# Altium schematic sheet DC Step-Down Converter.SchDoc
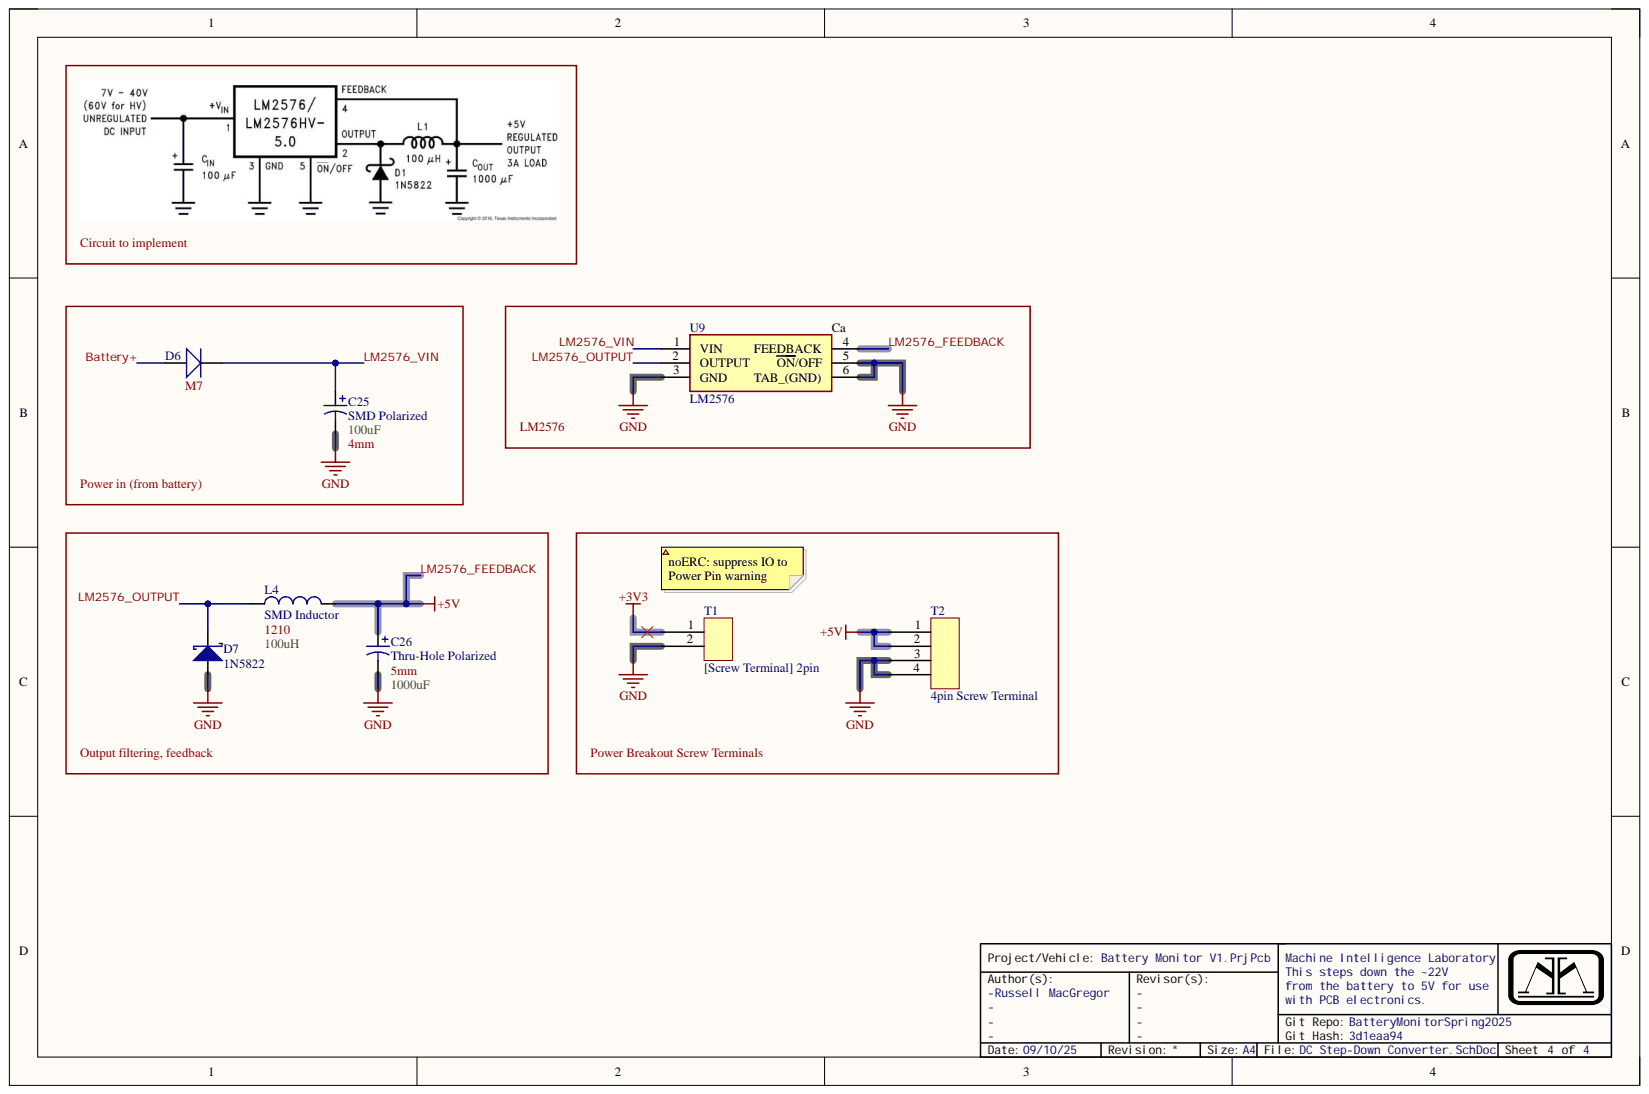
[diagram p0: DC Step-Down Converter.SchDoc]

## Parts
C25  SMD Polarized  Capacitance: 100uF  Diameter: 4mm  [[Cap] SMD Polarized, 4mm]
C26  Thru-Hole Polarized  Capacitance: 1000uF  Pin Spacing: 5mm  [[Cap] Thru-Hole Polarized 5mm]
D6  Size Package: M7  [[Diode] SMD M7]  (hidden comment: Rectifier)
D7  1N5822  [[Diode] Thru-Hole Schottky]
L4  SMD Inductor  Size Package: 1210  [[Inductor] SMD 1210]
T1  [Screw Terminal] 2pin  [[Screw Terminal] 2pin]
T2  4pin Screw Terminal  [[Screw Terminal] 4pin]
U9  LM2576  [[IC] Buck Converter]

## Nets
+3V3: T1.1
GND: C25.2 C26.2 D7.2 T1.2 T2.3 T2.4 U9.3(GND) U9.5(ON/OFF) U9.6(TAB_(GND))
Battery+: D6.2
LM2576_FEEDBACK: C26.1 L4.2 T2.1 T2.2 U9.4(FEEDBACK)
LM2576_OUTPUT: D7.1 L4.1 U9.2(OUTPUT)
LM2576_VIN: C25.1 D6.1 U9.1(VIN)

## Library data of the parts
C25 = [Cap] SMD Polarized: Component Kind: Standard; Library Name: RADM Components.IntLib
C26 = [Cap] Thru-Hole Polarized: Component Kind: Standard; Library Name: RADM Components.IntLib
D6 = [Diode] SMD Rectifier: Generic; Component Kind: Standard; Library Name: RADM Components.IntLib
D7 = [Diode] Thru-Hole Schottky: Component Kind: Standard; Description: 1N5822; Mouser Part #: 511-1N5822; Library Name: RADM Components.IntLib
L4 = [Inductor] SMD Inductor: Inductance: 100uH; Component Kind: Standard; Library Name: RADM Components.IntLib
T2 = [Screw Terminal] 4pin: Component Kind: Standard; Library Name: RADM Components.IntLib
U9 = [IC] Buck Converter: Component Kind: Standard; Description: LM2576; Library Name: RADM Components.IntLib
T1 = [Screw Terminal] 2pin: Component Kind: Standard; Library Name: RADM Components.IntLib
